# Altium schematic sheet ETH.SchDoc
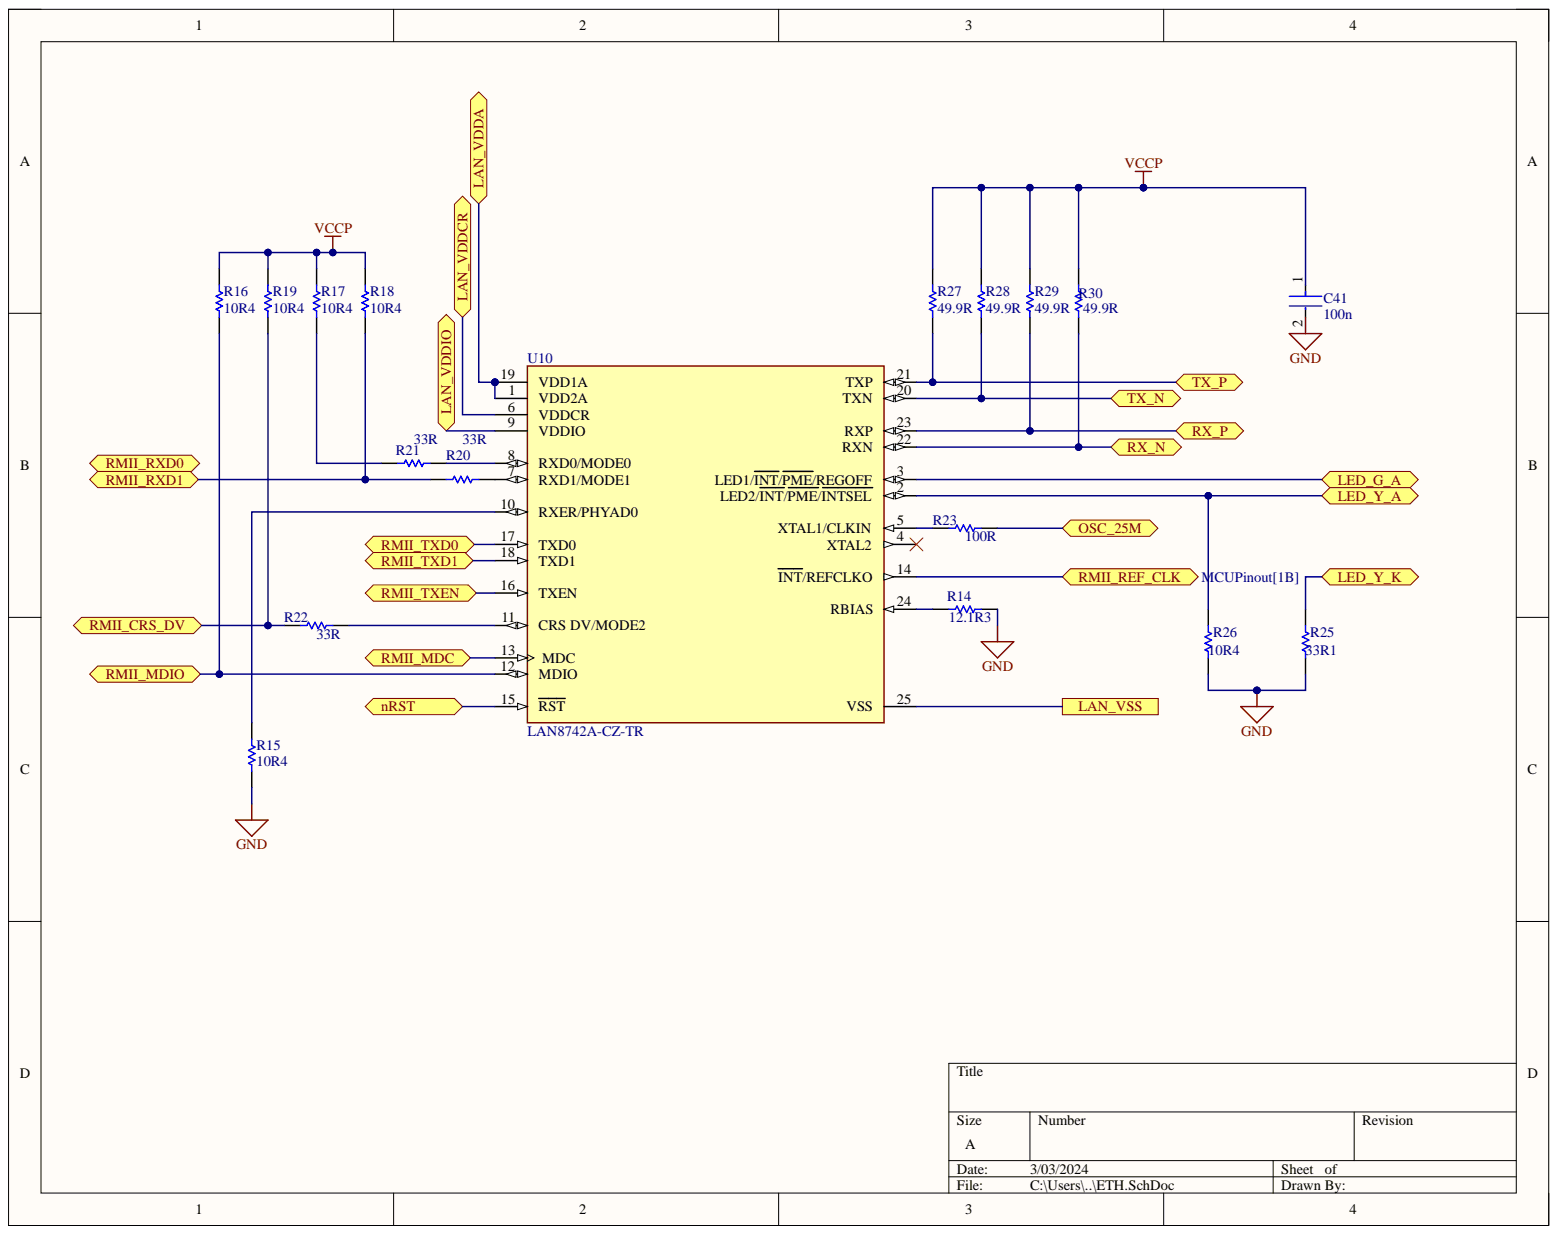
[diagram p0: ETH.SchDoc]

## Parts
C41  100n  [FP-GRM033-0_03-MFG]
R14  12.1R3  [RESC1608X55X30LL20T20]
R15  10R4  [RESC1608X55X30LL20T20]
R16  10R4  [RESC1608X55X30LL20T20]
R17  10R4  [RESC1608X55X30LL20T20]
R18  10R4  [RESC1608X55X30LL20T20]
R19  10R4  [RESC1608X55X30LL20T20]
R20  33R  [RESC1608X55X30LL20T20]
R21  33R  [RESC1608X55X30LL20T20]
R22  33R  [RESC1608X55X30LL20T20]
R23  100R  [RESC1608X55X30LL20T20]
R25  33R1  [RESC1608X55X30LL20T20]
R26  10R4  [RESC1608X55X30LL20T20]
R27  49.9R  [RESC1608X55X30LL20T20]
R28  49.9R  [RESC1608X55X30LL20T20]
R29  49.9R  [RESC1608X55X30LL20T20]
R30  49.9R  [RESC1608X55X30LL20T20]
U10  LAN8742A-CZ-TR  [FP-C04-143B-S4QFN-MFG]

## Ports
LAN_VDDA, LAN_VDDCR, LAN_VDDIO, LAN_VSS, LED_G_A, LED_Y_A, LED_Y_K, nRST, OSC_25M, RMII_CRS_DV, RMII_MDC, RMII_MDIO, RMII_REF_CLK, RMII_RXD0, RMII_RXD1, RMII_TXD0, RMII_TXD1, RMII_TXEN, RX_N, RX_P, TX_N, TX_P

## Nets
GND: C41.2 R14.2 R15.1 R25.1 R26.1
VCCP: C41.1 R16.2 R17.2 R18.2 R19.2 R27.2 R28.2 R29.2 R30.2
LAN_VDDA: U10.1(VDD2A) U10.19(VDD1A) ; port LAN_VDDA
LAN_VDDCR: U10.6(VDDCR) ; port LAN_VDDCR
LAN_VDDIO: U10.9(VDDIO) ; port LAN_VDDIO
LAN_VSS: U10.25(VSS) ; port LAN_VSS
LED_G_A: U10.3(LED1/INT/PME/REGOFF) ; port LED_G_A
LED_Y_A: R26.2 U10.2(LED2/INT/PME/INTSEL) ; port LED_Y_A
LED_Y_K: R25.2 ; port LED_Y_K
nRST: U10.15(RST) ; port nRST
OSC_25M: R23.2 ; port OSC_25M
RMII_CRS_DV: R19.1 R22.1 ; port RMII_CRS_DV
RMII_MDC: U10.13(MDC) ; port RMII_MDC
RMII_MDIO: R16.1 U10.12(MDIO) ; port RMII_MDIO
RMII_REF_CLK: U10.14(INT/REFCLKO) ; port RMII_REF_CLK
RMII_RXD1: R18.1 R20.1 ; port RMII_RXD1
RMII_TXD0: U10.17(TXD0) ; port RMII_TXD0
RMII_TXD1: U10.18(TXD1) ; port RMII_TXD1
RMII_TXEN: U10.16(TXEN) ; port RMII_TXEN
RX_N: R30.1 U10.22(RXN) ; port RX_N
RX_P: R29.1 U10.23(RXP) ; port RX_P
TX_N: R28.1 U10.20(TXN) ; port TX_N
TX_P: R27.1 U10.21(TXP) ; port TX_P
NetR14_1: R14.1 U10.24(RBIAS)
NetR15_2: R15.2 U10.10(RXER/PHYAD0)
NetR17_1: R17.1 R21.1
NetR20_2: R20.2 U10.7(RXD1/MODE1)
NetR21_2: R21.2 U10.8(RXD0/MODE0)
NetR22_2: R22.2 U10.11(CRS_DV/MODE2)
NetR23_1: R23.1 U10.5(XTAL1/CLKIN)
N00001: ; port RMII_RXD0

Not connected: U10 4(XTAL2)

## Library data of the parts
C41 = CMP-06035-000062-2: Chip Multilayer Ceramic Capacitors for General Purpose, 0201, 0.10uF, X5R, 15%, 10%, 6.3V; Capacitance: 100nF; Case Code (Imperial): 0201; Case Code (Metric): 0603; Case/Package: 0201; Component Kind: Standard; Depth: 0.3mm; Dielectric: X5R; Height: 0.3mm; Lead Free: Lead Free; Length: 0.6mm; Library Name: Altium Content Vault; Material: Ceramic; Max Operating Temperature: 85°C; Min Operating Temperature: -55°C; Mount: Surface Mount; Package Quantity: 1; Packaging: Tape and Reel; Radiation Hardening: No; REACH SVHC: No SVHC; Termination: SMD/SMT; Thickness: 0.013inch; Tolerance: 10%; Voltage: 6.3V; Voltage Rating: 6.3V; Voltage Rating (DC): 6.3V; Weight: 6E-06oz; Width: 0.012inch
R14-R23 R25-R30 = CMP-2000-00025-1: Manufacturer: Panasonic; Part Number: ERA3AEB301V; Component Kind: Standard; Library Name: Altium Content Vault
U10 = CMP-06281-000006-2: IC TRANSCEIVER 1/1 24SQFN; Manufacturer: Microchip; Data Rate: 100Mbits/s; Interface: Ethernet,Other; Max Supply Voltage: 3.6V; Min Supply Voltage: 1.14V; Nominal Supply Current: 6.5-59mA; Number Of Channels: 1; Simplex Duplex: Full-Duplex; Standoff Height: 0mm; Ambient Temperature Range High: +70°C; Ambient Temperature Range Low: 0°C; Automotive: No; Category: IC; Component Kind: Standard; ComponentLink2Description: Datasheet; ComponentLink2URL: http://ww1.microchip.com/downloads/en/DeviceDoc/DS_LAN8742_00001989A.pdf; Device Class L1: Integrated Circuits (ICs); Device Class L2: Interface ICs; Device Class L3: Ethernet Interface ICs; Digikey Description: IC TRANSCEIVER 1/1 24SQFN; Height: 1mm; Ipc Land Pattern Name: QFN50P400X400X90-24; Lead Free: Yes; Library Name: Altium Content Vault; Manufacturer Part Number: LAN8742A-CZ-TR; Mouser Description: Small Footprint RMII 10/100 Ethernet Transceiver with flexPWR Technology; Octopart: https://octopart.com/lan8742a-cz-tr-microchip-29030587; Package: C04-143B-S4QFN; RoHS: TRUE; Temperature: 0°C to +70°C; Temperature Range High: +70°C; Temperature Range Low: 0°C
